# Altium schematic sheet ESP32.SchDoc(ESP32)
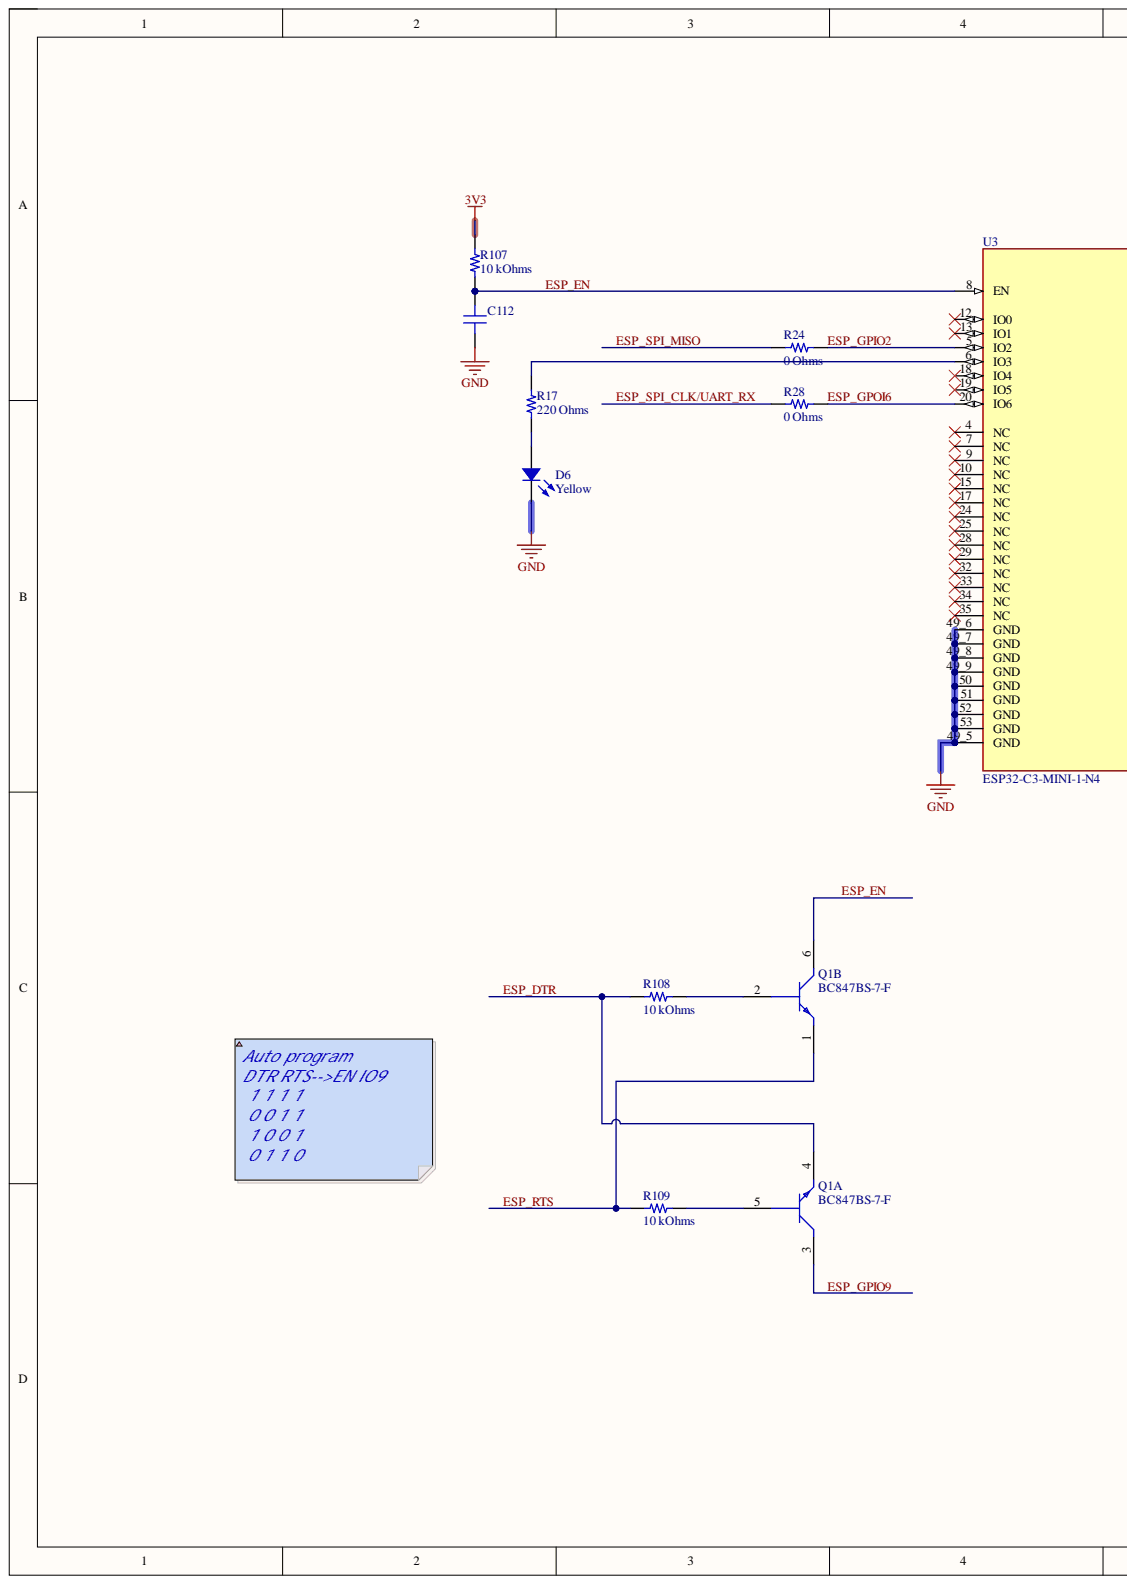
[diagram p0: ESP32.SchDoc, left]
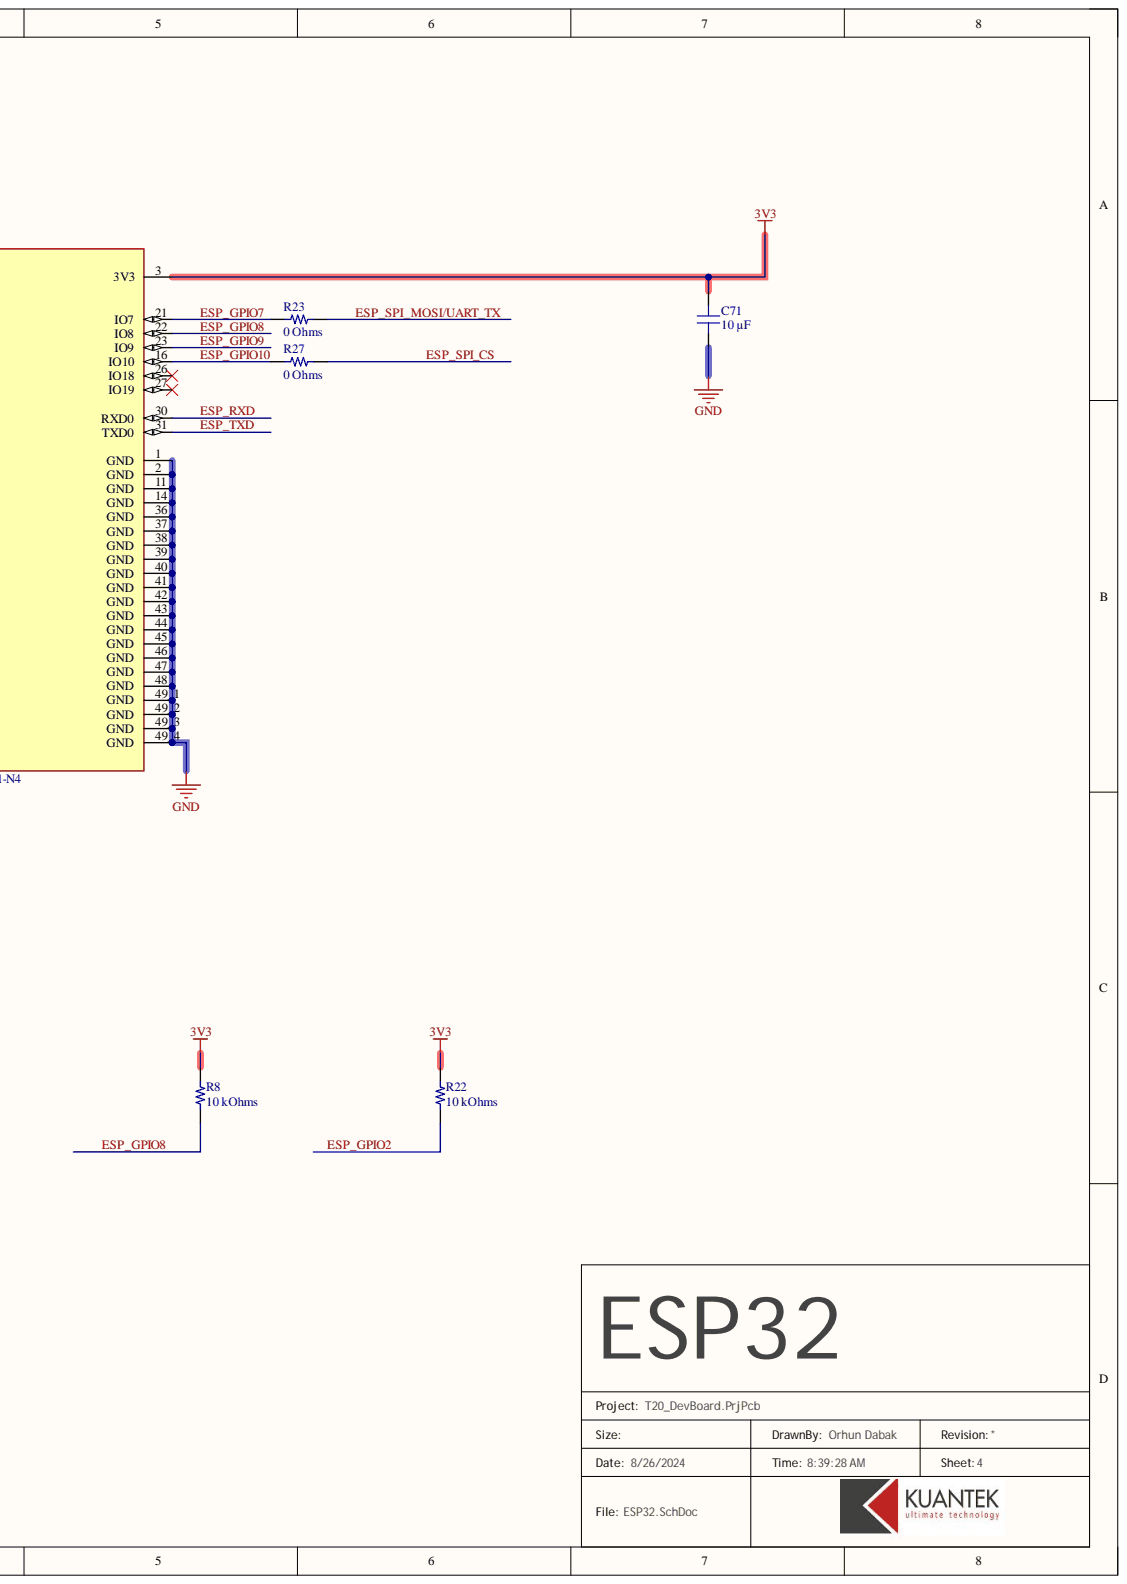
[diagram p1: ESP32.SchDoc, right]

## Parts
C71  10 µF  [CAP 0603_1608]
C112  1 µF  [CAP 0402_1005]  (value not drawn)
D6  Yellow  [LED 0603_1608 YELLOW]
Q1  BC847BS-7-F  [SOT65P210X110-6N]  (parts A,B)
R8  10 kOhms  [RES 0402_1005]
R17  220 Ohms  [RES 0402_1005]
R22  10 kOhms  [RES 0402_1005]
R23  0 Ohms  [RES 0402_1005]
R24  0 Ohms  [RES 0402_1005]
R27  0 Ohms  [RES 0402_1005]
R28  0 Ohms  [RES 0402_1005]
R107  10 kOhms  [RES 0402_1005]
R108  10 kOhms  [RES 0402_1005]
R109  10 kOhms  [RES 0402_1005]
U3  ESP32-C3-MINI-1-N4  [XCVR_ESP32-C3-MINI-1-N4]

## Nets
3V3: C71.2 R8.2 R22.1 R107.2 U3.3(3V3)
GND: C71.1 C112.1 D6.2 U3.1(GND) U3.2(GND) U3.11(GND) U3.14(GND) U3.36(GND) U3.37(GND) U3.38(GND) U3.39(GND) U3.40(GND) U3.41(GND) U3.42(GND) U3.43(GND) U3.44(GND) U3.45(GND) U3.46(GND) U3.47(GND) U3.48(GND) U3.49_1(GND) U3.49_2(GND) U3.49_3(GND) U3.49_4(GND) U3.49_5(GND) U3.49_6(GND) U3.49_7(GND) U3.49_8(GND) U3.49_9(GND) U3.50(GND) U3.51(GND) U3.52(GND) U3.53(GND)
ESP_DTR: Q1.4 R108.2
ESP_EN: C112.2 Q1.6 R107.1 U3.8(EN)
ESP_GPIO2: R22.2 R24.2 U3.5(IO2)
ESP_GPIO7: R23.1 U3.21(IO7)
ESP_GPIO8: R8.1 U3.22(IO8)
ESP_GPIO9: Q1.3 U3.23(IO9)
ESP_GPIO10: R27.1 U3.16(IO10)
ESP_GPOI6: R28.2 U3.20(IO6)
ESP_RTS: Q1.1 R109.2
ESP_RXD: U3.30(RXD0)
ESP_SPI_CLK/UART_RX: R28.1
ESP_SPI_CS: R27.2
ESP_SPI_MISO: R24.1
ESP_SPI_MOSI/UART_TX: R23.2
ESP_TXD: U3.31(TXD0)
NetD6_1: D6.1 R17.2
NetQ1_2: Q1.2 R108.1
NetQ1_5: Q1.5 R109.1
NetR17_1: R17.1 U3.6(IO3)

Not connected: U3 4(NC) 7(NC) 9(NC) 10(NC) 12(IO0) 13(IO1) 15(NC) 17(NC) 18(IO4) 19(IO5) 24(NC) 25(NC) 26(IO18) 27(IO19) 28(NC) 29(NC) 32(NC) 33(NC) 34(NC) 35(NC)

## Library data of the parts
C71 = GRM188R60J106ME47D: CAP CER 10UF 6.3V X5R 0603; manufacturer: Murata Electronics; Database Table Name: Capacitors - Ceramic - 0603; Tolerance: ±20%; Applications: General Purpose; Capacitance: 10 µF; Component Kind: Standard; componentlink1description: Datasheet; componentlink1url: https://www.murata.com/~/media/webrenewal/support/library/catalog/products/capacitor/mlcc/c02e.ashx?la=en-us; componentlink2description: DigiKey Link; componentlink2url: https://www.digikey.com/en/products/detail/murata-electronics/GRM188R60J106ME47D/965910; Device Package: 0603 (1608 Metric); lastupdated: 9/19/2022 01:01:20 PM; Library Name: Celestial Altium Library - altium_library.DbLib; Lifecycle Status: Active; Minimum Order: 1; Mounting Type: Surface Mount, MLCC; Operating Temperature: -55°C ~ 85°C; Package / Case: 0603 (1608 Metric); packaging: TapeAndReel; Part Number: GRM188R60J106ME47D; Part Status: Active; partid: 2351; price: 0.02; series: GRM; Size / Dimension: 0.063" L x 0.031" W (1.60mm x 0.80mm); Supplier 1: DigiKey; Supplier Part Number 1: 490-3896-1-ND; Temperature Coefficient: X5R; Thickness (Max): 0.035" (0.90mm); Value: 10 µF; Voltage - Rated: 6.3V
C112 = GRM155R70J105KA12J: CAP CER 1UF 6.3V X7R 0402; manufacturer: Murata Electronics; Database Table Name: Capacitors - Ceramic - 0402; Tolerance: ±10%; Applications: General Purpose; Capacitance: 1 µF; Component Kind: Standard; componentlink1description: Datasheet; componentlink1url: https://search.murata.co.jp/Ceramy/image/img/A01X/G101/ENG/GRM155R70J105KA12-01.pdf; componentlink2description: DigiKey Link; componentlink2url: https://www.digikey.com/en/products/detail/murata-electronics/GRM155R70J105KA12J/4905166; Device Package: 0402 (1005 Metric); lastupdated: 19/09/2022 12:57:31; Library Name: Celestial Altium Library - altium_library.DbLib; Lifecycle Status: Active; Minimum Order: 1; Mounting Type: Surface Mount, MLCC; Operating Temperature: -55°C ~ 125°C; Package / Case: 0402 (1005 Metric); packaging: TapeAndReel; Part Number: GRM155R70J105KA12J; Part Status: Active; partid: 2890; price: 0.01; series: GRM; Size / Dimension: 0.039" L x 0.020" W (1.00mm x 0.50mm); Supplier 1: DigiKey; Supplier Part Number 1: 490-13339-1-ND; Temperature Coefficient: X7R; Thickness (Max): 0.022" (0.55mm); Value: 1 µF; Voltage - Rated: 6.3V
D6 = SML-LX0603YW-TR: LED YELLOW DIFFUSED SMD; Manufacturer: Lumex Opto/Components Inc.; Configuration: Standard; Current - Test: 20mA; Database Table Name: LED - Indication; Lens Color: White; Lens Size: 1.00mm x 0.80mm; Lens Style: Rectangle with Flat Top; Millicandela Rating: 14mcd; Viewing Angle: 160°; Voltage - Forward (Vf) (Typ): 2.1V; Wavelength - Peak: 585nm; Color: Yellow; Component Kind: Standard; ComponentLink1Description: Datasheet; ComponentLink1URL: https://www.lumex.com/content/files/ProductAttachment/SML-LX0603YW-TR.pdf; ComponentLink2Description: DigiKey Link; ComponentLink2URL: https://www.digikey.com/en/products/detail/lumex-opto-components-inc/SML-LX0603YW-TR/304361; Device Package: 0603 (1608 Metric); Height (Max): 0.60mm; LastUpdated: 19/09/2022 13:51:05; Lens Transparency: Diffused; Library Name: Celestial Altium Library - altium_library.DbLib; Lifecycle Status: Active; Minimum Order: 1; Mounting Type: Surface Mount; Package / Case: 0603 (1608 Metric); Packaging: TapeAndReel; Part Number: SML-LX0603YW-TR; Part Status: Active; PartId: 92; Price: 0.05; Series: -; Size / Dimension: 1.60mm L x 0.80mm W; Supplier 1: DigiKey; Supplier Part Number 1: 67-1550-1-ND; Value: Yellow
Q1 = BC847BS-7-F: Transistor BJT NPN; Manufacturer_Name: Diodes Incorporated; Arrow Part Number: BC847BS-7-F; Arrow Price/Stock: https://www.arrow.com/en/products/bc847bs-7-f/diodes-incorporated?region=nac; Component Kind: Standard; Datasheet Link: https://www.diodes.com/assets/Datasheets/ds30222.pdf; Height: 1.1mm; Library Name: SamacSys.SchLib; Manufacturer_Part_Number: BC847BS-7-F; Mouser Part Number: 621-BC847BS-7-F; Mouser Price/Stock: https://www.mouser.co.uk/ProductDetail/Diodes-Incorporated/BC847BS-7-F?qs=rGAXPo9uwV3sf9v%2FHEcaVA%3D%3D
R8 R22 R107-R109 = RC0402JR-0710KL: RES 10K OHM 5% 1/16W 0402; Manufacturer: Yageo; Database Table Name: Resistors - Surface Mount - 0402; Power (Watts): 0.063W, 1/16W; Temperature Coefficient: ±100ppm/°C; Component Kind: Standard; ComponentLink1Description: Datasheet; ComponentLink1URL: https://www.yageo.com/upload/media/product/productsearch/datasheet/rchip/PYu-RC_Group_51_RoHS_L_10.pdf; ComponentLink2Description: DigiKey Link; ComponentLink2URL: https://www.digikey.com/en/products/detail/yageo/RC0402JR-0710KL/726418; Composition: Thick Film; Device Package: 0402 (1005 Metric); Features: Moisture Resistant; Height - Seated (Max): 0.016" (0.40mm); LastUpdated: 4/7/2023 07:22:44 PM; Library Name: Celestial Altium Library - altium_library.DbLib; Lifecycle Status: Active; Minimum Order: 1; Number of Terminations: 2; Operating Temperature: -55°C ~ 155°C; Package / Case: 0402 (1005 Metric); Packaging: CutTape; Part Number: RC0402JR-0710KL; Part Status: Active; PartId: 20526; Price: 0.01; Resistance: 10 kOhms; Series: RC_L; Size / Dimension: 0.039" L x 0.020" W (1.00mm x 0.50mm); Supplier 1: DigiKey; Supplier Device Package: 0402; Supplier Part Number 1: 311-10KJRCT-ND; Tolerance: ±5%; Value: 10 kOhms
R17 = RC0402FR-07220RL: RES 220 OHM 1% 1/16W 0402; Manufacturer: Yageo; Database Table Name: Resistors - Surface Mount - 0402; Power (Watts): 0.063W, 1/16W; Temperature Coefficient: ±100ppm/°C; Component Kind: Standard; ComponentLink1Description: Datasheet; ComponentLink1URL: https://www.yageo.com/upload/media/product/productsearch/datasheet/rchip/PYu-RC_Group_51_RoHS_L_10.pdf; ComponentLink2Description: DigiKey Link; ComponentLink2URL: https://www.digikey.com/en/products/detail/yageo/RC0402FR-07220RL/726565; Composition: Thick Film; Device Package: 0402 (1005 Metric); Features: Moisture Resistant; Height - Seated (Max): 0.016" (0.40mm); LastUpdated: 19/09/2022 13:14:14; Library Name: Celestial Altium Library - altium_library.DbLib; Lifecycle Status: Active; Minimum Order: 1; Number of Terminations: 2; Operating Temperature: -55°C ~ 155°C; Package / Case: 0402 (1005 Metric); Packaging: CutTape; Part Number: RC0402FR-07220RL; Part Status: Active; PartId: 17956; Price: 0.01; Resistance: 220 Ohms; Series: RC_L; Size / Dimension: 0.039" L x 0.020" W (1.00mm x 0.50mm); Supplier 1: DigiKey; Supplier Device Package: 0402; Supplier Part Number 1: 311-220LRCT-ND; Tolerance: ±1%; Value: 220 Ohms
R23 R24 R27 R28 = AC0402FR-070RL: RESISTOR 0402 JUMPER ZERO OHM; Manufacturer: Yageo; Database Table Name: Resistors - Surface Mount - 0402; Power (Watts): 0.063W, 1/16W; Component Kind: Standard; ComponentLink1Description: Datasheet; ComponentLink1URL: https://www.yageo.com/upload/media/product/productsearch/datasheet/rchip/PYu-AC_51_RoHS_L_8.pdf; ComponentLink2Description: DigiKey Link; ComponentLink2URL: https://www.digikey.com/en/products/detail/yageo/AC0402FR-070RL/12082398; Composition: Thick Film; Device Package: 0402 (1005 Metric); Features: Automotive AEC-Q200, Moisture Resistant; Height - Seated (Max): 0.015" (0.37mm); LastUpdated: 12/6/2023 08:26:55 PM; Library Name: Celestial Altium Library - altium_library.DbLib; Lifecycle Status: Active; Minimum Order: 1; Number of Terminations: 2; Operating Temperature: -55°C ~ 155°C; Package / Case: 0402 (1005 Metric); Packaging: CutTape; Part Number: AC0402FR-070RL; Part Status: Active; PartId: 66976; Price: 0.01; Ratings: AEC-Q200; Resistance: 0 Ohms; Series: AC; Size / Dimension: 0.039" L x 0.020" W (1.00mm x 0.50mm); Supplier 1: DigiKey; Supplier Device Package: 0402; Supplier Part Number 1: 13-AC0402FR-070RLCT-ND; Tolerance: Jumper; Value: 0 Ohms
U3 = ESP32-C3-MINI-1-N4: Bluetooth, WiFi 802.11b/g/n, Bluetooth v5.0 Transceiver Module 2.412GHz ~ 2.484GHz PCB Trace Surface Mount; MF: Espressif Systems; Check_prices: https://www.snapeda.com/parts/ESP32-C3-MINI-1-N4/Espressif+Systems/view-part/?ref=eda; Component Kind: Standard; MP: ESP32-C3-MINI-1-N4; Package: SMD-53 Espressif Systems; SnapEDA_Link: https://www.snapeda.com/parts/ESP32-C3-MINI-1-N4/Espressif+Systems/view-part/?ref=snap; Library Name: Kuantek_SO-DIMM.SchLib
